# Altium schematic sheet J1708 Ignition for T to E.SchDoc("J1708 Ignition for T to E")
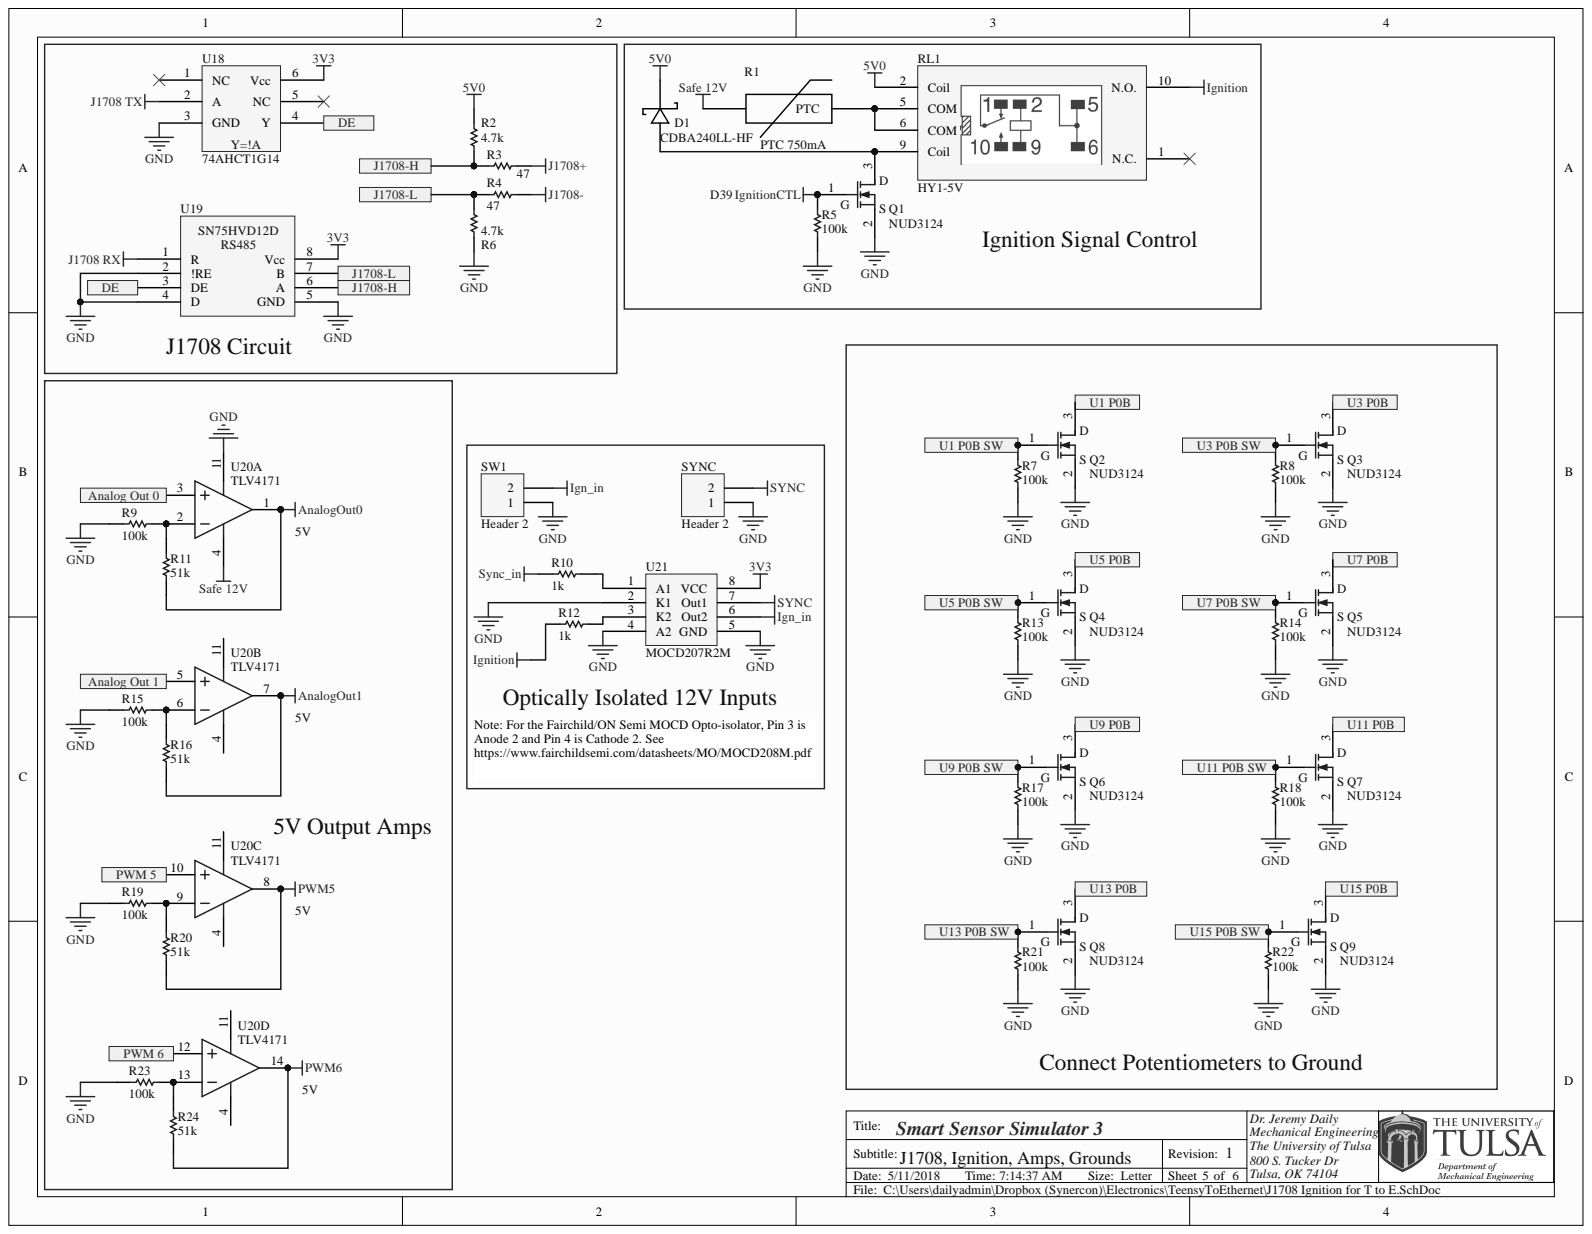
[diagram p0: J1708 Ignition for T to E.SchDoc]

## Parts
D1  CDBA240LL-HF  [SMA with A and K]
Q1  NUD3124  [ONSC-CPH-3_V]
Q2  NUD3124  [ONSC-CPH-3_V]
Q3  NUD3124  [ONSC-CPH-3_V]
Q4  NUD3124  [ONSC-CPH-3_V]
Q5  NUD3124  [ONSC-CPH-3_V]
Q6  NUD3124  [ONSC-CPH-3_V]
Q7  NUD3124  [ONSC-CPH-3_V]
Q8  NUD3124  [ONSC-CPH-3_V]
Q9  NUD3124  [ONSC-CPH-3_V]
R1  PTC 750mA  [1206]
R2  4.7k  [0603]
R3  47  [0603]
R4  47  [0603]
R5  100k  [0603]
R6  4.7k  [0603]
R7  100k  [0603]
R8  100k  [0603]
R9  100k  [0603]
R10  1k  [0603]
R11  51k  [0603]
R12  1k  [0603]
R13  100k  [0603]
R14  100k  [0603]
R15  100k  [0603]
R16  51k  [0603]
R17  100k  [0603]
R18  100k  [0603]
R19  100k  [0603]
R20  51k  [0603]
R21  100k  [0603]
R22  100k  [0603]
R23  100k  [0603]
R24  51k  [0603]
RL1  HY1-5V  [OMRON G5V Relay]
SW1  Header 2  [HDR1X2]
SYNC  Header 2  [HDR1X2]
U18  74AHCT1G14  [SOT-353]
U19  SN75HVD12D (3.3v RS485)  [SOIC-8]  (value not drawn)
U20  TLV4171  [TI-PW14_M]  (parts A,B,C,D)
U21  MOCD207R2M  [SOIC-8]

## Ports
Analog Out 0, Analog Out 1, DE, J1708-H, J1708-L, PWM 5, PWM 6, U1 P0B, U1 P0B SW, U3 P0B, U3 P0B SW, U5 P0B, U5 P0B SW, U7 P0B, U7 P0B SW, U9 P0B, U9 P0B SW, U11 P0B, U11 P0B SW, U13 P0B, U13 P0B SW, U15 P0B, U15 P0B SW

## Nets
3V3: U18.6(Vcc) U19.8(Vcc) U21.8(VCC)
5V0: D1.2 R2.1 RL1.2(Coil)
AnalogOut0: R11.2 U20.1
AnalogOut1: R16.2 U20.7
GND: Q1.2 Q2.2 Q3.2 Q4.2 Q5.2 Q6.2 Q7.2 Q8.2 Q9.2 R5.1 R6.2 R7.1 R8.1 R9.2 R13.1 R14.1 R15.2 R17.1 R18.1 R19.2 R21.1 R22.1 R23.2 SW1.1 SYNC.1 U18.3(GND) U19.2(!RE) U19.4(D) U19.5(GND) U20.11 U21.2(K1) U21.4(A2) U21.5(GND)
Ign_in: SW1.2 U21.6(Out2)
Ignition: R12.2 RL1.10(N.O.)
IgnitionCTL: Q1.1 R5.2
J1708 RX: U19.1(R)
J1708 TX: U18.2(A)
J1708+: R3.2
J1708-: R4.2
PWM5: R20.2 U20.8
PWM6: R24.2 U20.14
Safe 12V: R1.1 U20.4
SYNC: SYNC.2 U21.7(Out1)
Sync_in: R10.2
Analog Out 0: U20.3 ; port Analog Out 0
Analog Out 1: U20.5 ; port Analog Out 1
DE: U18.4(Y) U19.3(DE) ; port DE
J1708-H: R2.2 R3.1 U19.6(A) ; port J1708-H
J1708-L: R4.1 R6.1 U19.7(B) ; port J1708-L
PWM 5: U20.10 ; port PWM 5
PWM 6: U20.12 ; port PWM 6
U1 P0B: Q2.3 ; port U1 P0B
U1 P0B SW: Q2.1 R7.2 ; port U1 P0B SW
U3 P0B: Q3.3 ; port U3 P0B
U3 P0B SW: Q3.1 R8.2 ; port U3 P0B SW
U5 P0B: Q4.3 ; port U5 P0B
U5 P0B SW: Q4.1 R13.2 ; port U5 P0B SW
U7 P0B: Q5.3 ; port U7 P0B
U7 P0B SW: Q5.1 R14.2 ; port U7 P0B SW
U9 P0B: Q6.3 ; port U9 P0B
U9 P0B SW: Q6.1 R17.2 ; port U9 P0B SW
U11 P0B: Q7.3 ; port U11 P0B
U11 P0B SW: Q7.1 R18.2 ; port U11 P0B SW
U13 P0B: Q8.3 ; port U13 P0B
U13 P0B SW: Q8.1 R21.2 ; port U13 P0B SW
U15 P0B: Q9.3 ; port U15 P0B
U15 P0B SW: Q9.1 R22.2 ; port U15 P0B SW
NetD1_1: D1.1 Q1.3 RL1.9(Coil)
NetR1_2: R1.2 RL1.5(COM) RL1.6(COM)
NetR9_1: R9.1 R11.1 U20.2
NetR10_1: R10.1 U21.1(A1)
NetR12_1: R12.1 U21.3(K2)
NetR15_1: R15.1 R16.1 U20.6
NetR19_1: R19.1 R20.1 U20.9
NetR23_1: R23.1 R24.1 U20.13

Not connected: RL1 1(N.C.); U18 1(NC) 5(NC)

## Library data of the parts
D1 = Schottky Diode: Diode Schottky 40V 2A Surface Mount DO-214AC (SMA); Manufacturer: Comchip Technology; Alternative: Acceptable; Supplier Part Number 1: 641-1698-1-ND; Supplier 1: Digi-Key; PartNumber: CDBA240LL-HF; Library Name: SSS Integrated Library.IntLib; Component Kind: Standard
Q1-Q9 = IRLML6344TRPbF: IC INDCT LOAD DRVR AUTO SOT23; PartNumber: NUD3124LT1G; Manufacturer: ON Semiconductor; Alternative: None; Supplier Part Number 1: NUD3124LT1GOSCT-ND; Supplier 1: Digi-Key; Library Name: SSS Integrated Library.IntLib; Component Kind: Standard
R1 = PTC: PTC RESTTBLE 0.75A 16V CHIP 1206; PartNumber: 0ZCJ0075AF2E; Manufacturer: Bel Fuse Inc; Alternative: None; Supplier Part Number 1: 507-1805-1-ND; Supplier 1: Digi-Key; Library Name: SSS Integrated Library.IntLib; Component Kind: Standard
R2 R6 = Chip Resistor: 4.7 kOhms ±1% 0.1W, 1/10W Chip Resistor 0603 (1608 Metric) Automotive AEC-Q200 Thick Film; PartNumber: ERJ-3EKF4701V; Manufacturer: Panasonic Electronic Components; Alternative: Acceptable; Supplier Part Number 1: P4.70KHCT-ND; Supplier 1: Digi-Key; Library Name: SSS Integrated Library.IntLib; Component Kind: Standard
R3 R4 = Chip Resistor: 47 Ohms ±1% 0.1W, 1/10W Chip Resistor 0603 (1608 Metric) Automotive AEC-Q200 Thick Film; PartNumber: ERJ-3EKF47R0V; Manufacturer: Panasonic Electronic Components; Alternative: Acceptable; Supplier Part Number 1: P47.0HCT-ND; Supplier 1: Digi-Key; Library Name: SSS Integrated Library.IntLib; Component Kind: Standard
R5 R7-R9 R13-R15 R17-R19 R21-R23 = Chip Resistor: 100 kOhms ±1% 0.1W, 1/10W Chip Resistor 0603 (1608 Metric) Automotive AEC-Q200 Thick Film; PartNumber: ERJ-3EKF1003V; Manufacturer: Panasonic Electronic Components; Alternative: Acceptable; Supplier Part Number 1: P100KHCT-ND; Supplier 1: Digi-Key; Library Name: SSS Integrated Library.IntLib; Component Kind: Standard
R10 R12 = Chip Resistor: RES SMD 1K OHM 1% 1/10W 0603; PartNumber: ERJ-3EKF1001V; Manufacturer: Panasonic Electronic Components; Alternative: Acceptable; Supplier Part Number 1: P1.00KHCT-ND; Supplier 1: Digi-Key; Library Name: SSS Integrated Library.IntLib; Component Kind: Standard
R11 R16 R20 R24 = Chip Resistor: RES SMD 51K OHM 1% 1/10W 0603; PartNumber: ERJ-3EKF5102V; Manufacturer: Panasonic Electronic Components; Alternative: Acceptable; Supplier Part Number 1: P51.0KHCT-ND; Supplier 1: Digi-Key; Library Name: SSS Integrated Library.IntLib; Component Kind: Standard
RL1 = Relay-G5V: Telecom Relay SPDT (1 Form C) Through Hole; Manufacturer: Panasonic Electric Works; Alternative: None; Supplier Part Number 1: 255-1092-ND; Supplier 1: Digi-Key; PartNumber: HY1-5V; Library Name: SSS Integrated Library.IntLib; Component Kind: Standard
SW1 SYNC = Header 2: Header, 2-Pin; Alternative: None; Signal Integrity: Connector; Library Name: Miscellaneous Connectors.IntLib; Component Kind: Standard
U18 = 74AHCT1G14 Inverter: IC SCHMIT-TRIGG INV GATE SOT353; PartNumber: 74AHCT1G14SE-7; Manufacturer: Diodes Incorporated; Alternative: Acceptable; Supplier Part Number 1: 74AHCT1G14SE-7DICT-ND; Supplier 1: Digi-Key; Library Name: SSS Integrated Library.IntLib; Component Kind: Standard
U19 = SN75HVD12D (3.3v RS485): IC RS485 TXRX 3/5V 8-SOIC; PartNumber: SN75HVD08DR; Manufacturer: Texas Instruments; Alternative: None; Supplier Part Number 1: 296-37893-1-ND; Supplier 1: Digi-Key; Library Name: SSS Integrated Library.IntLib; Component Kind: Standard
U20 = TL064CPW: Quad Low-Power JFET-Input General-Purpose Operational Amplifier, 7 to 36 V, 0 to 70 degC, 14-pin SOP (PW14), Green (RoHS & no Sb/Br); PartNumber: TLV4171IPWR; Manufacturer: Texas Instruments; Alternative: None; Supplier Part Number 1: 296-44866-1-ND; Supplier 1: Digi-Key; Library Name: TI Amplifiers and Linear Operational Amplifier General Purpose.IntLib; Component Kind: Standard
U21 = Optoisolator - Dual: Optoisolator Transistor Output 2500Vrms 2 Channel 8-SO Tall; Manufacturer: Fairchild/ON Semiconductor; Alternative: None; Supplier Part Number 1: MOCD207R2MCT-ND; Supplier 1: Digi-Key; PartNumber: MOCD207R2M; Library Name: TU Custom Parts Schematics.SchLib; Component Kind: Standard
